# Altium schematic sheet Headset_tester.SchDoc
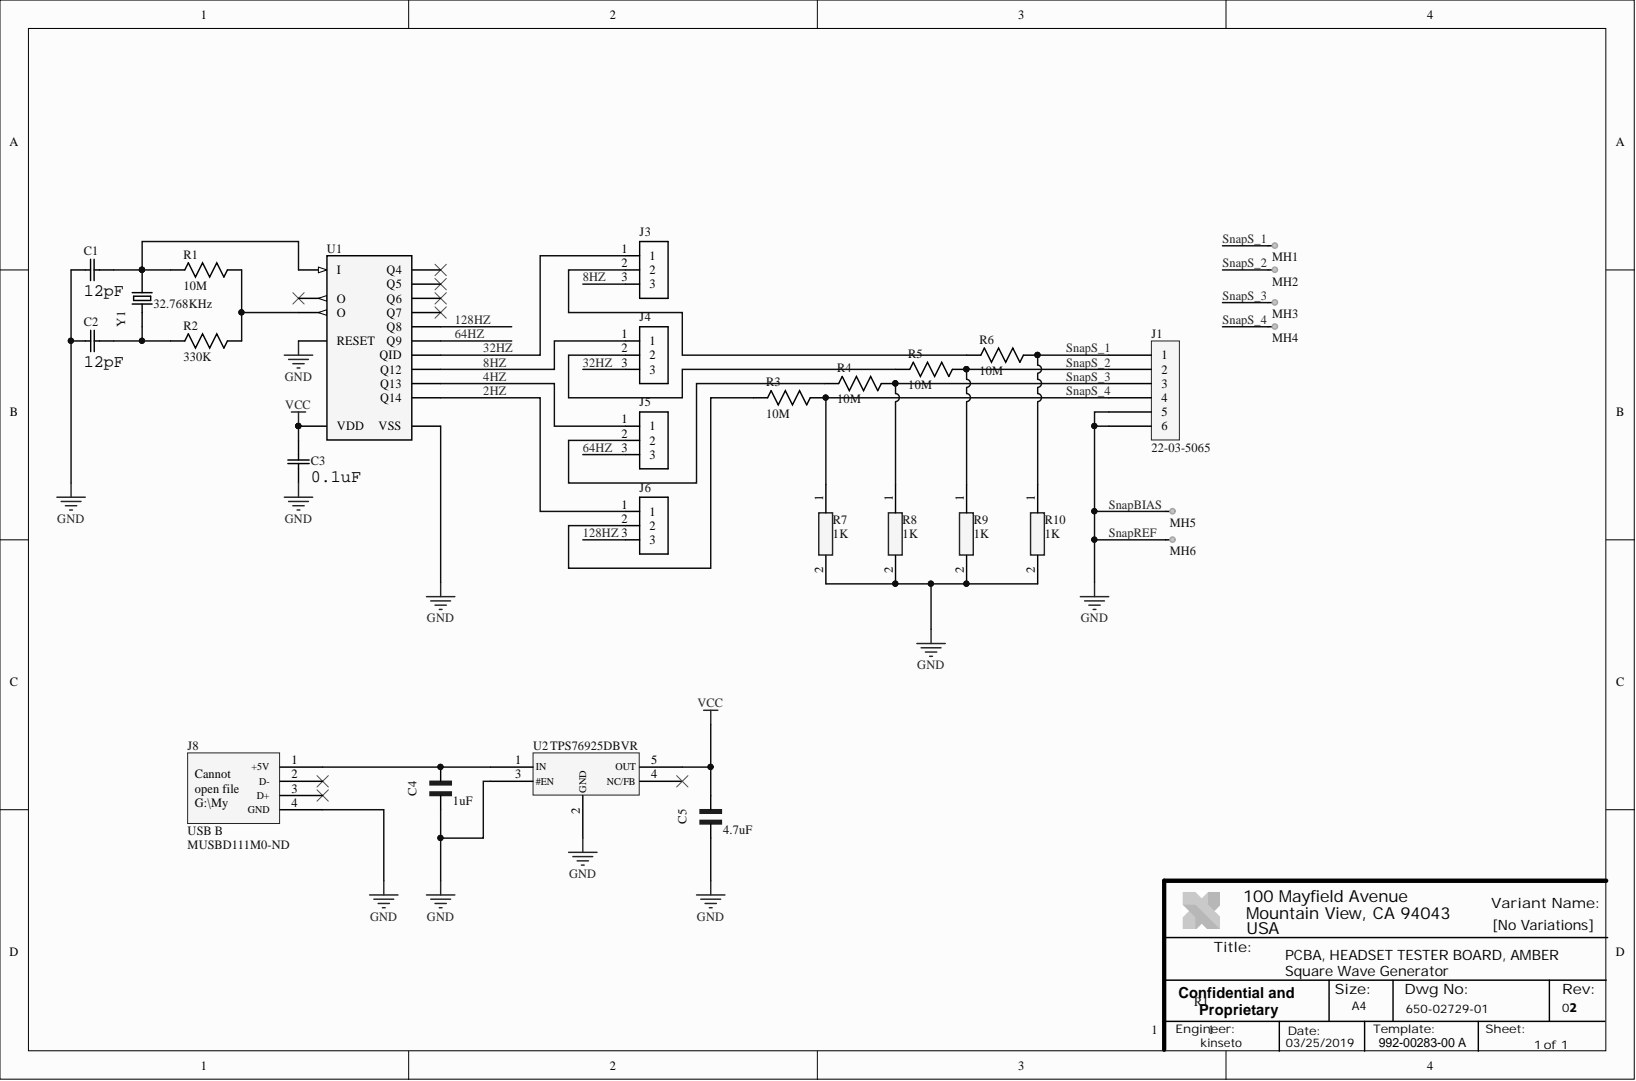
[diagram p0: Headset_tester.SchDoc]

## Parts
C1  value 12pF  [CC0805N]  (hidden comment: Part Number: CC0805JRNPO9BN120)
C2  value 12pF  [CC0805N]  (hidden comment: Part Number: CC0805JRNPO9BN120)
C3  value 0.1uF  [0805]  (hidden comment: Part Number: 08053C104JAT2A)
C4  value 1uF  [*CAPC2012X140N]  (hidden comment: CL21B105KAFNNNE)
C5  value 4.7uF  [*CAPC2012X135N]  (hidden comment: GRM219R61A475KE34D)
J1  22-03-5065  [MOLEX 22-03-5065]
J3  M20-9990345  [*HDRV3W64P254_1X3_762X254X910P]  (value not drawn)
J4  M20-9990345  [*HDRV3W64P254_1X3_762X254X910P]  (value not drawn)
J5  M20-9990345  [*HDRV3W64P254_1X3_762X254X910P]  (value not drawn)
J6  M20-9990345  [*HDRV3W64P254_1X3_762X254X910P]  (value not drawn)
J8  USB B  Supplier Part Number 1: MUSBD111M0-ND  [MUSBD111M0]
MH1  _Template_Mech  [91_Stud]  (value not drawn)
MH2  _Template_Mech  [91_Stud]  (value not drawn)
MH3  _Template_Mech  [91_Stud]  (value not drawn)
MH4  _Template_Mech  [91_Stud]  (value not drawn)
MH5  _Template_Mech  [76_Stud]  (value not drawn)
MH6  _Template_Mech  [76_Stud]  (value not drawn)
R1  value 10M  [0805]  (hidden comment: ERJ-6GEYJ106V)
R2  value 330K  [0805]  (hidden comment: ERA-6AEB334V)
R3  value 10M  [0805]  (hidden comment: ERJ-6GEYJ106V)
R4  value 10M  [0805]  (hidden comment: ERJ-6GEYJ106V)
R5  value 10M  [0805]  (hidden comment: ERJ-6GEYJ106V)
R6  value 10M  [0805]  (hidden comment: ERJ-6GEYJ106V)
R7  1K  [RESC2012X60N]
R8  1K  [RESC2012X60N]
R9  1K  [RESC2012X60N]
R10  1K  [RESC2012X60N]
U1  CD4060BPWR  [PW16]  (value not drawn)
U2  TPS76925DBVR  [SOT-23-5]
Y1  value 32.768KHz  [CFS-20632768DZFB]  (hidden comment: CFS-20632768DZFB)

## Nets
VCC: C3.2 C5.1 U1.16 U2.5
2HZ: J6.1 U1.3
4HZ: J5.1 U1.2
8HZ: J3.3 J4.1 U1.1
32HZ: J3.1 J4.3 U1.15
64HZ: J5.3 U1.13
128HZ: J6.3 U1.14
SnapBIAS: C1.1 C2.2 C3.1 C4.2 C5.2 J1.5 J1.6 J8.4 MH5.1 MH6.1 R7.2 R8.2 R9.2 R10.2 U1.8 U1.12 U2.2 U2.3
SnapS_1: J1.1 MH1.1 R6.1 R10.1
SnapS_2: J1.2 MH2.1 R5.1 R9.1
SnapS_3: J1.3 MH3.1 R4.1 R8.1
SnapS_4: J1.4 MH4.1 R3.1 R7.1
NetC1_2: C1.2 R1.2 U1.11 Y1.2
NetC2_1: C2.1 R2.2 Y1.1
NetC4_1: C4.1 J8.1 U2.1
NetJ3_2: J3.2 R6.2
NetJ4_2: J4.2 R5.2
NetJ5_2: J5.2 R4.2
NetJ6_2: J6.2 R3.2
NetR1_1: R1.1 R2.1 U1.10

Not connected: J8 2 3; U1 4 5 6 7 9; U2 4

## Library data of the parts
C1 C2 = CC0805JRNPO9BN12: No Description Available; Built_By: EMA_UL_Team; Type: DEV; Vendor: Yageo; Component Kind: Standard; Library Name: CC0805JRNPO9BN120.IntLib; Manufacturer_Part_Number: CC0805JRNPO9BN120; RefDes: RefDes; Copyright: Copyright (C) 2018 Accelerated Designs. All rights reserved
C3 = 08053C104JAT2A: No Description Available; Built_By: EMA_UL_Team; Type: DEV; Vendor: AVX Corporation; Component Kind: Standard; Library Name: 08053C104JAT2A.IntLib; Manufacturer_Part_Number: 08053C104JAT2A; RefDes: RefDes; Copyright: Copyright (C) 2018 Accelerated Designs. All rights reserved
C4 = CL21B105KAFNNNE: CL21 Series 1 uF 25 V Â±10% Tolerance X7R SMT Multilayer Ceramic Capacitor; MF: Samsung Electro-Mechanics; Component Kind: Standard; Digi-Key_Part_Number: 1276-1066-1-ND; Digi-Key_Purchase_URL: https://www.digikey.com/product-detail/en/samsung-electro-mechanics/CL21B105KAFNNNE/1276-1066-1-ND/3889152?utm_source=snapeda&utm_medium=aggregator&utm_campaign=symbol; Library Name: CL21B105KAFNNNE.SchLib; MP: CL21B105KAFNNNE; Package: 0805 Samsung; SnapEDA_Link: https://www.snapeda.com/parts/CL21B105KAFNNNE/Samsung%20Electro-Mechanics/view-part/?ref=snap
C5 = GRM219R61A475KE34D: 0805 4.7 uF 10 V Â±10% Tolerance X5R Surface Mount Multilayer Ceramic Capacitor; MF: Murata; Component Kind: Standard; Digi-Key_Part_Number: 490-3339-1-ND; Digi-Key_Purchase_URL: https://www.digikey.com/product-detail/en/murata-electronics-north-america/GRM219R61A475KE34D/490-3339-1-ND/702880?utm_source=snapeda&utm_medium=aggregator&utm_campaign=symbol; Library Name: GRM219R61A475KE34D.SchLib; MP: GRM219R61A475KE34D; Package: 0805 Murata; SnapEDA_Link: https://www.snapeda.com/parts/GRM219R61A475KE34D/Murata%20Electronics%20North%20America/view-part/?ref=snap
J1 = 22-03-5065: CONN HEADER VERT 6POS 2.5MM; Component Kind: Standard; Library Name: 22-03-5065.IntLib; PN: 22-03-5065; Supplier: Molex
J3-J6 = M20-9990345: Conn Unshrouded Header HDR 3 POS 2.54mm Solder ST Thru-Hole Bag; MF: Harwin Inc.; Component Kind: Standard; Digi-Key_Part_Number: 952-2263-ND; Digi-Key_Purchase_URL: https://www.digikey.com/product-detail/en/harwin-inc/M20-9990345/952-2263-ND/3728227?utm_source=snapeda&utm_medium=aggregator&utm_campaign=symbol; Library Name: M20-9990345.IntLib; MP: M20-9990345; Package: None; SnapEDA_Link: https://www.snapeda.com/parts/M20-9990345/Harwin%20Inc./view-part/?ref=snap
J8 = 292304-1: Manufacturer: TE Connectivity AMP Connectors; Connector Type: USB - B; Current Rating: 1A per Contact; Gender: Receptacle; Material Flammability Rating: UL94 V-0; Mating Cycles: 1500; Number of Contacts: 4; Number of Ports: 1; Shielding: Shielded; Specifications: USB 2.0; Voltage - Rated: 30VAC; Category: Connectors, Interconnects; Component Kind: Standard; ComponentLink1Description: http://www.te.com/commerce/DocumentDelivery/DDEController?Action=srchrtrv&DocNm=292304&DocType=Customer+Drawing&DocLang=English; ComponentLink1URL: http://www.te.com/commerce/DocumentDelivery/DDEController?Action=srchrtrv&DocNm=292304&DocType=Customer+Drawing&DocLang=English; Contact Finish: Gold or Gold-Palladium; Features: Board Lock; Ingress Protection: -; Insulation Color: Black; Manufacturer Part Number: 292304-1; Mounting Feature: Horizontal; Mounting Type: Through Hole, Right Angle; Operating Temperature: -55°C ~ 85°C; Packaging: Tray; Part Status: Active; RoHS: RoHS Compliant; Shell/Shielding Material: Copper Alloy; Supplier 1: Digi-Key; Termination: Solder; Library Name: Hlibrary.SchLib
MH1-MH4 = 121: HW, SNAP, STUD, 0.128 in LG, 0.490in OD, BRASS, AMBER; Database Table Name: Mechanical; KOI: 250-07859-00; MFG: Rome Fastener Corporation; MFG P/N: 91 Stud; Component Kind: Standard; Height: 3.3782mm; ID: 121; Library Name: AltiumReleaseLibDB.DBLib
MH5 MH6 = 120: HW, SNAP, STUD, 3.2mm LG, OD 7.5mm, .130 SERIES, BRASS, AMBER; Database Table Name: Mechanical; KOI: 250-07858-00; MFG: Rome Fastener Corporation; MFG P/N: 76 Stud; Component Kind: Standard; Height: 3.35mm; ID: 120; Library Name: AltiumReleaseLibDB.DBLib
R1 R3-R6 = ERJ-6GEYJ106V: RES SMD 10M OHM 5% 1/8W 0805; Component Kind: Standard; Library Name: SMD_Resistors.IntLib; PN: ERJ-6GEYJ106V; Supplier: Panasonic Electronic Components
R2 = ERA-6AEB334V: RES SMD 330K OHM 0.1% 1/8W 0805; Component Kind: Standard; Library Name: SMD_Resistors.IntLib; PN: ERA-6AEB334V; Supplier: Panasonic Electronic Components
R7-R9 = CRCW08051K00FKEAC: Resistor; Manufacturer_Name: Vishay; Component Kind: Standard; Datasheet Link: https://www.vishay.com/docs/28773/crcwce3.pdf; Height: 0.6mm; Manufacturer_Part_Number: CRCW08051K00FKEAC; Mouser Part Number: 71-CRCW08051K00FKEAC; Mouser Price/Stock: https://www.mouser.com/Search/Refine.aspx?Keyword=71-CRCW08051K00FKEAC
R10 = CRCW08051K00FKEAC: Resistor 0805 1K; Manufacturer_Name: Vishay; Component Kind: Standard; Datasheet Link: https://www.vishay.com/docs/28773/crcwce3.pdf; Height: 0.6mm; Manufacturer_Part_Number: CRCW08051K00FKEAC; Mouser Part Number: 71-CRCW08051K00FKEAC; Mouser Price/Stock: https://www.mouser.com/Search/Refine.aspx?Keyword=71-CRCW08051K00FKEAC
U1 = CD4060BPWR: Manufacturer: Texas Instruments; Built_By: EMA_UL_Team; Component Kind: Standard; Description: CD4060BPWR; Manufacturer_Part_Number: CD4060BPWR; RefDes: RefDes; Type: DEV; Value: Value; Vendor: Vendor; Copyright: Copyright (C) 2018 Accelerated Designs. All rights reserved; Library Name: CD4060_TSSOP.IntLib
U2 = TPS76925DBVR: Manufacturer: Texas Instruments; Current - Output: 100mA; Description1: IC REG LDO 2.5V 0.1A SOT23-5; Number of Regulators: 1; Regulator Topology: Positive Fixed; Voltage - Input: 2.7 V ~ 10 V; Voltage - Output: 2.5V; Category: Integrated Circuits (ICs); Component Kind: Standard; ComponentLink1Description: http://www.ti.com/lit/ds/symlink/tps769.pdf; ComponentLink1URL: http://www.ti.com/lit/ds/symlink/tps769.pdf; Current - Limit (Min): -; Mounting Type: Surface Mount; Operating Temperature: -40°C ~ 125°C; Package / Case: SC-74A, SOT-753; Packaging: Cut Tape (CT); Part Status: Active; Pricing 1: 1=0.87, 10=0.775, 100=0.6044, 500=0.4998, 1000=0.39525 (USD); RoHS: RoHS Compliant; Stock 1: 9031; Supplier 1: Digi-Key; Supplier Device Package: SOT-23-5; Supplier Part Number 1: 296-11035-1-ND; Voltage - Dropout (Typical): -; Library Name: LUCHADOR.IntLib
Y1 = CFS-20632768DZFB: CRYSTAL 32.7680KHZ 12.5PF T/H; MF: Citizen Finedevice Co Ltd; Component Kind: Standard; Digi-Key_Part_Number: 300-1002-ND; Digi-Key_Purchase_URL: https://www.digikey.com/product-detail/en/citizen-finedevice-co-ltd/CFS-20632768DZFB/300-1002-ND/283736; Library Name: CFS-20632768DZFB.IntLib; MP: CFS-20632768DZFB; Package: Cylindrical Can Radial  ECS International
